# Altium schematic sheet CAMERA.SchDoc(CAMERA)
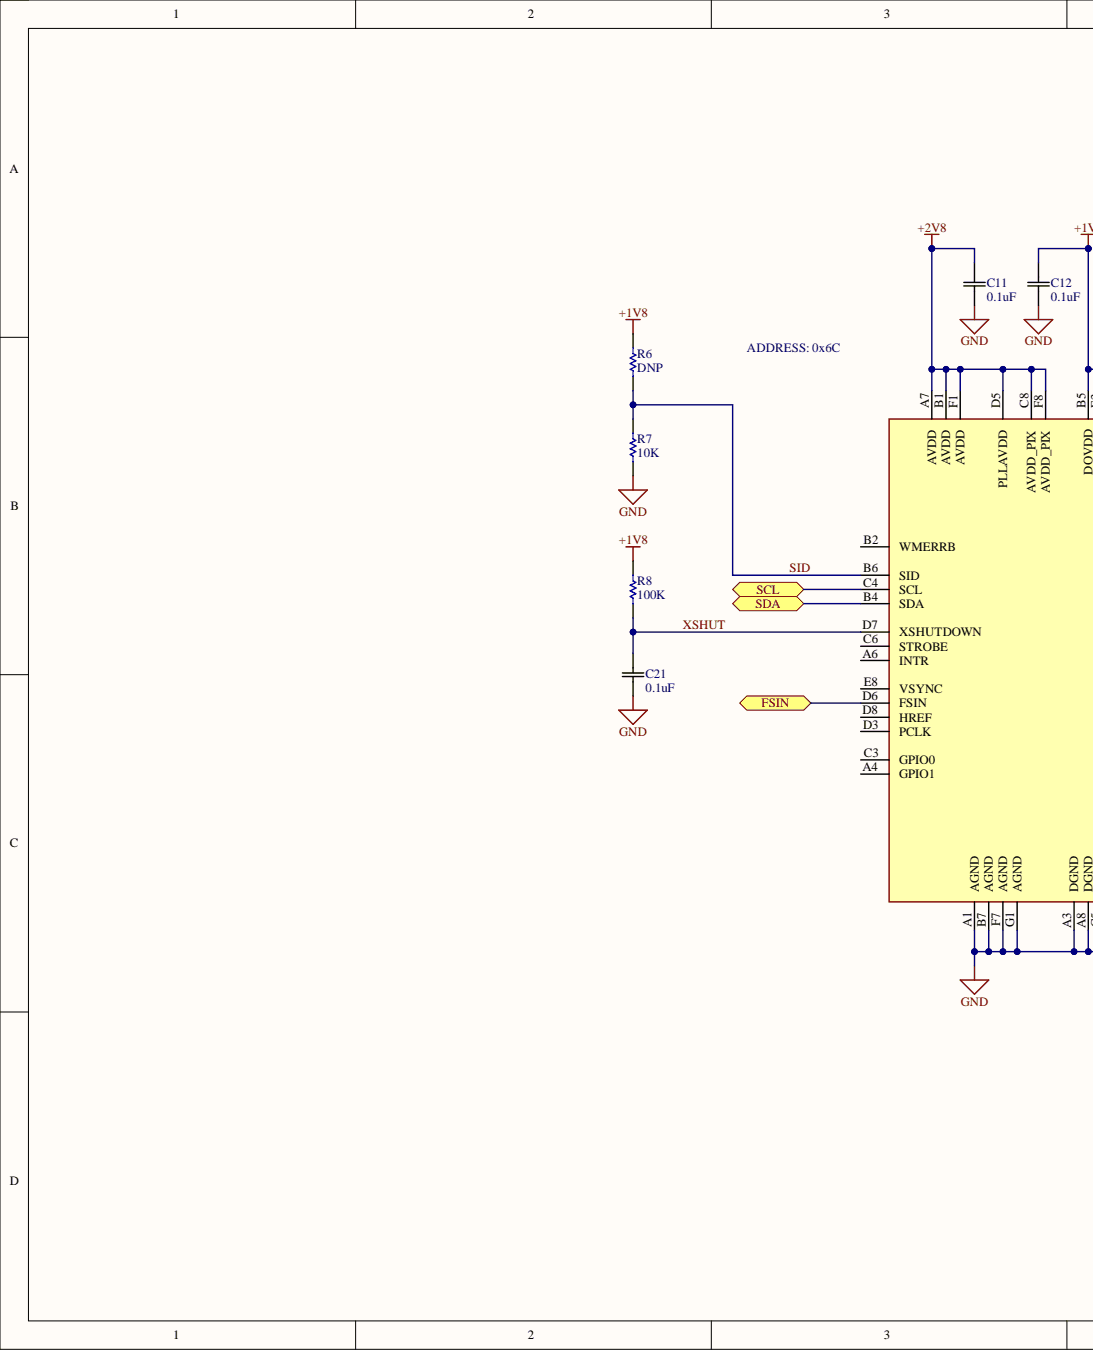
[diagram p0: CAMERA.SchDoc, left]
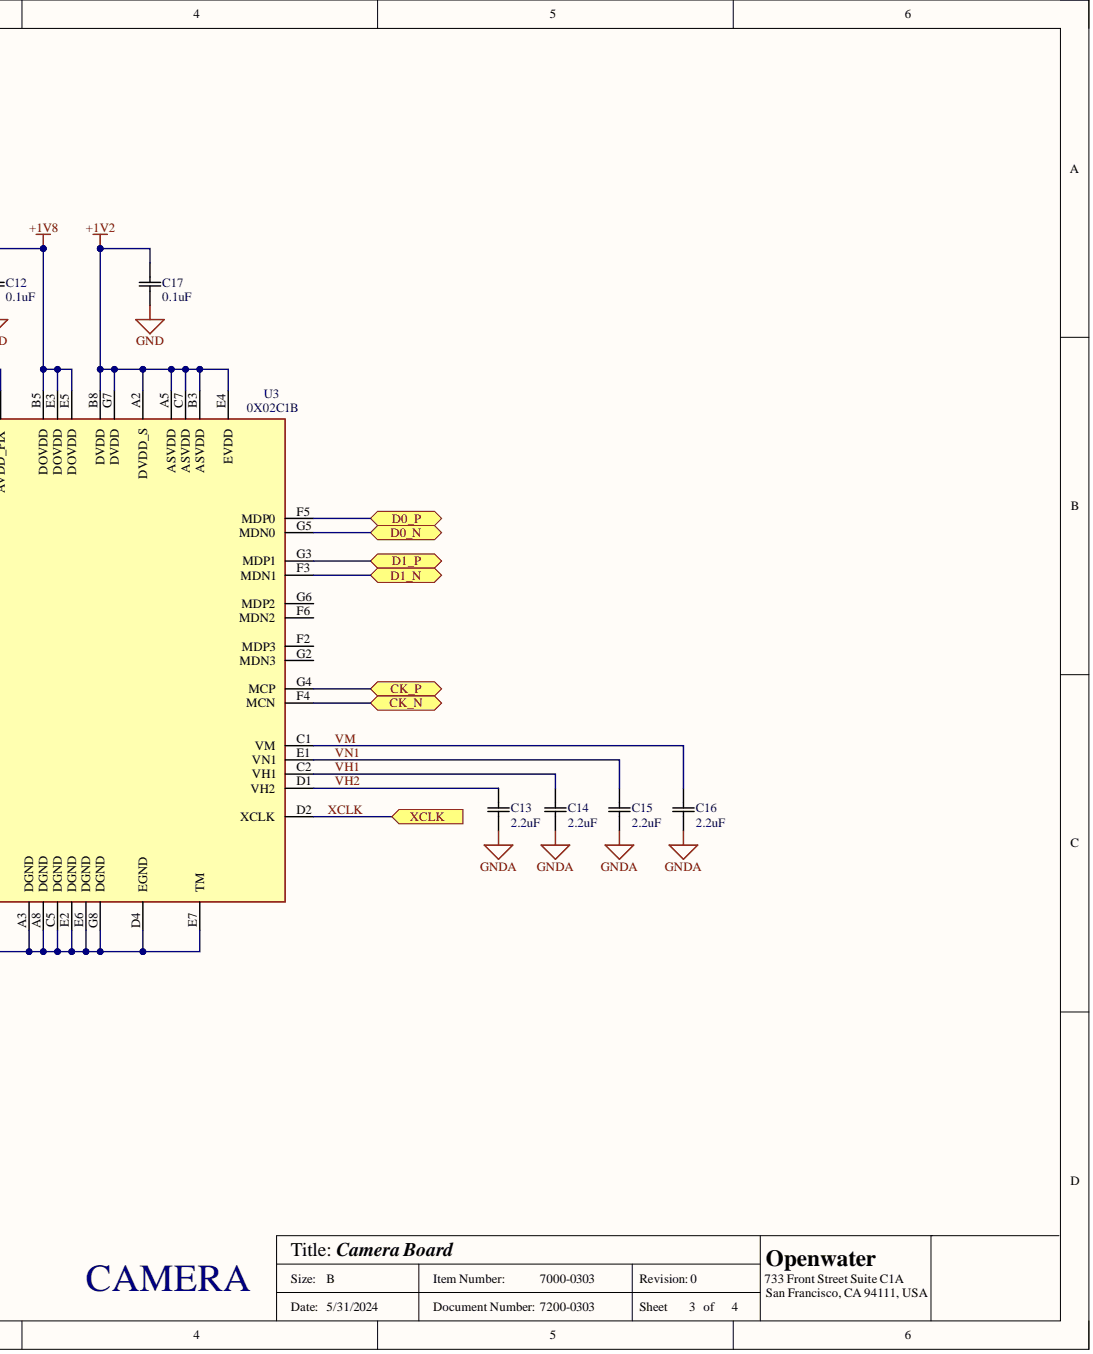
[diagram p1: CAMERA.SchDoc, right]

## Parts
C11  0.1uF
C12  0.1uF
C13  2.2uF
C14  2.2uF
C15  2.2uF
C16  2.2uF
C17  0.1uF
C21  0.1uF
R6  DNP
R7  10K
R8  100K
U3  0X02C1B

## Ports
CK_N, CK_P, D0_N, D0_P, D1_N, D1_P, FSIN, SCL, SDA, XCLK

## Nets
+1V2: C17.2 U3.A2(DVDD_S) U3.A5(ASVDD) U3.B3(ASVDD) U3.B8(DVDD) U3.C7(ASVDD) U3.E4(EVDD) U3.G7(DVDD)
+1V8: C12.2 R6.2 R8.2 U3.B5(DOVDD) U3.E3(DOVDD) U3.E5(DOVDD)
+2V8: C11.2 U3.A7(AVDD) U3.B1(AVDD) U3.C8(AVDD_PIX) U3.D5(PLLAVDD) U3.F1(AVDD) U3.F8(AVDD_PIX)
GND: C11.1 C12.1 C17.1 C21.1 R7.1 U3.A1(AGND) U3.A3(DGND) U3.A8(DGND) U3.B7(AGND) U3.C5(DGND) U3.D4(EGND) U3.E2(DGND) U3.E6(DGND) U3.E7(TM) U3.F7(AGND) U3.G1(AGND) U3.G8(DGND)
GNDA: C13.1 C14.1 C15.1 C16.1
CK_N: U3.F4(MCN) ; port CK_N
CK_P: U3.G4(MCP) ; port CK_P
D0_N: U3.G5(MDN0) ; port D0_N
D0_P: U3.F5(MDP0) ; port D0_P
D1_N: U3.F3(MDN1) ; port D1_N
D1_P: U3.G3(MDP1) ; port D1_P
FSIN: U3.D6(FSIN) ; port FSIN
SCL: U3.C4(SCL) ; port SCL
SDA: U3.B4(SDA) ; port SDA
SID: R6.1 R7.2 U3.B6(SID)
VH1: C14.2 U3.C2(VH1)
VH2: C13.2 U3.D1(VH2)
VM: C16.2 U3.C1(VM)
VN1: C15.2 U3.E1(VN1)
XCLK: U3.D2(XCLK) ; port XCLK
XSHUT: C21.2 R8.1 U3.D7(XSHUTDOWN)

Not connected: U3 A4(GPIO1) A6(INTR) B2(WMERRB) C3(GPIO0) C6(STROBE) D3(PCLK) D8(HREF) E8(VSYNC) F2(MDP3) F6(MDN2) G2(MDN3) G6(MDP2)

## Library data of the parts
C11 C12 C17 C21 = GRM033R60J104KE19D: CAP,0.1µF ±10% 6.3V Ceramic Capacitor X5R 0201 (0603 Metric); Mfr_Name: Yageo; Component Kind: Standard; Library Name: 7000-0xxx Camera PCA Rev 0.SCHLIB
C13-C16 = GRM033R60J225ME47D: CAP,2.2µF ±10% 6.3V Ceramic Capacitor X5R 0201 (0603 Metric); Mfr_Name: Yageo; Component Kind: Standard; Library Name: 7000-0xxx Camera PCA Rev 0.SCHLIB
R6 R8 = CRCW0201100KFNED: RES, 100 kOhms Â±1% 0.05W, 1/20W Chip Resistor 0201 _0603 Metric_ Thick Film; MF: Vishay Dale; Availability: Unavailable; Component Kind: Standard; Library Name: 7000-0xxx Camera PCA Rev 0.SCHLIB; MP: CRCW0201100KFNED; Package: 0603 Vishay; Price: None; SnapEDA_Link: https://www.snapeda.com/parts/CRCW0201100KFNED/Vishay%20Dale/view-part/3656241/?ref=snap
R7 = CRCW020110K0FNED: RES, 10 kOhms Â±1% 0.05W, 1/20W Chip Resistor 0201 _0603 Metric_ Thick Film; MF: Vishay Dale; MP: CRCW0201100KFNED; Availability: Unavailable; Component Kind: Standard; Library Name: 7000-0xxx Camera PCA Rev 0.SCHLIB; Package: 0603 Vishay; Price: None; SnapEDA_Link: https://www.snapeda.com/parts/CRCW0201100KFNED/Vishay%20Dale/view-part/3656241/?ref=snap
U3 = 0X02C1B: IC, Camersa Sensor; Manufacturer_Name: Microchip; Manufacturer_Part_Number: A3P030-VQG100I; Geometry.Height: 1.2mm; Component Kind: Standard; Datasheet Link: https://www.microsemi.com/document-portal/doc_download/130704-ds0097-proasic3-family-flash-fpgas-datasheet; Library Name: 7000-0xxx Camera PCA Rev 0.SCHLIB; Mouser Part Number: 494-A3P030-VQG100I; Mouser Price/Stock: https://www.mouser.co.uk/ProductDetail/Microchip-Technology/A3P030-VQG100I?qs=sScscP4KMkhNAy2pUobyCQ%3D%3D
